# Altium schematic sheet Circuit_SCS_Error.SchDoc(Circuit_SCS_Error)
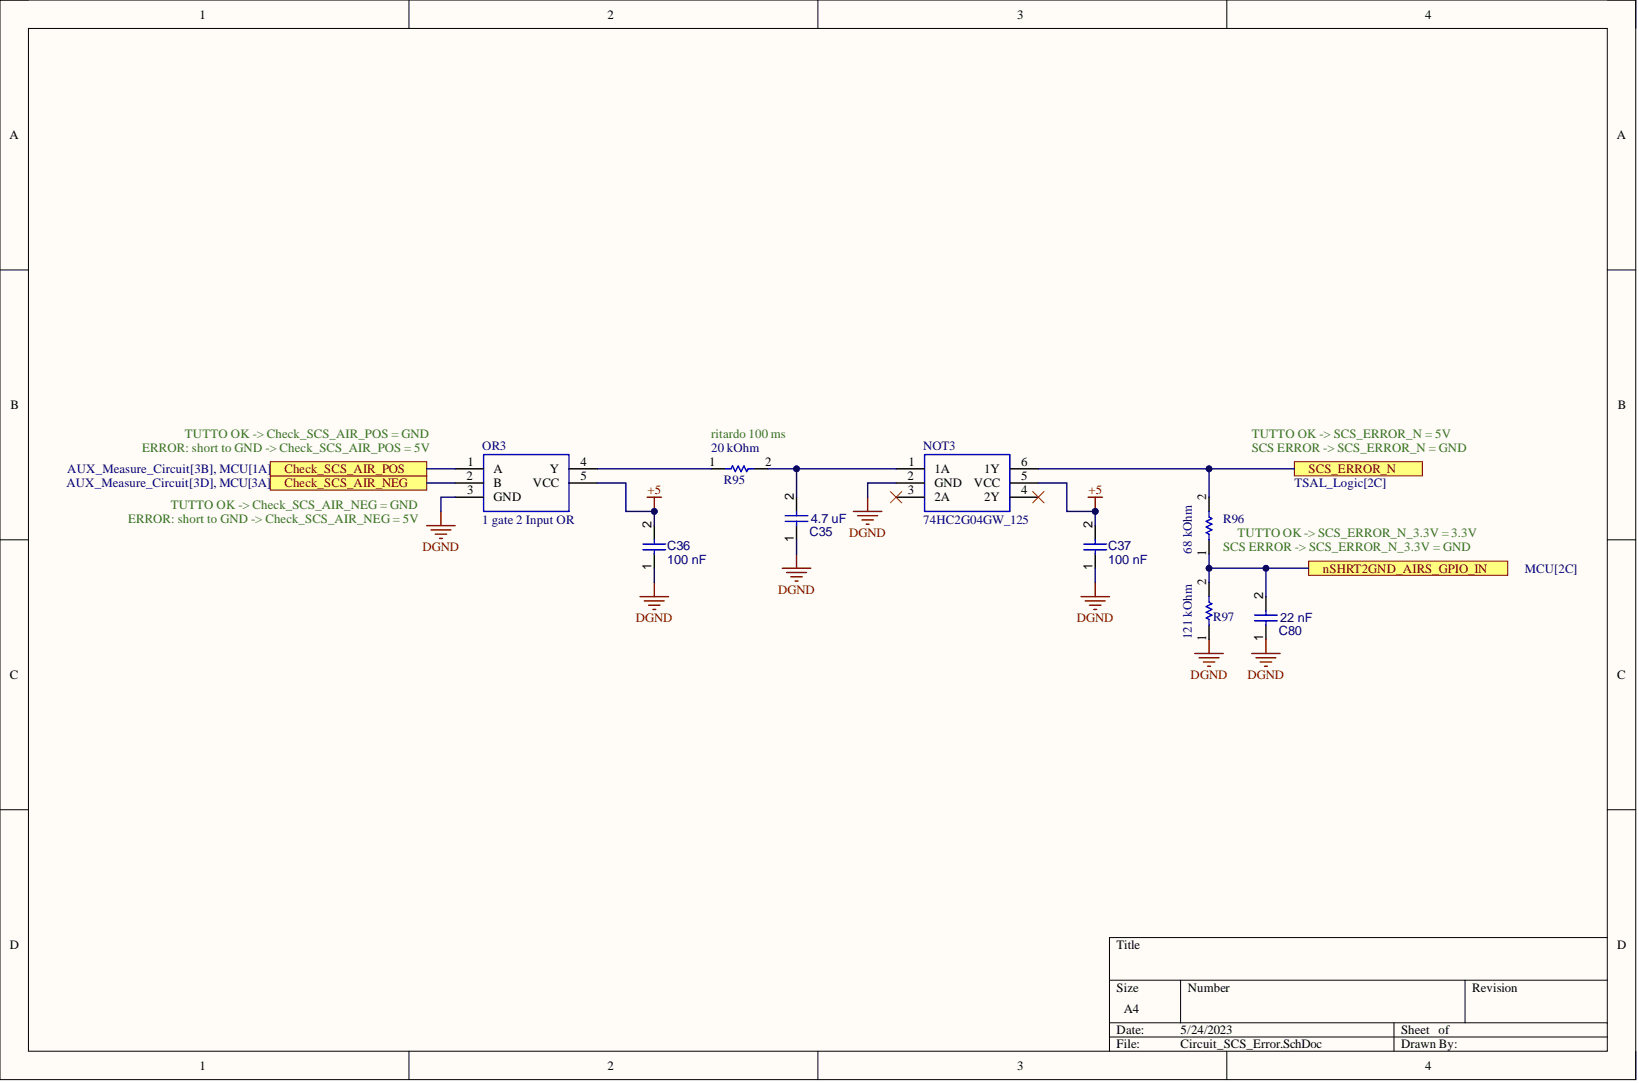
[diagram p0: Circuit_SCS_Error.SchDoc]

## Parts
C35  4.7 uF
C36  100 nF
C37  100 nF
C80  22 nF
NOT3  74HC2G04GW_125
OR3  1 gate 2 Input OR
R95  20 kOhm
R96  68 kOhm
R97  121 kOhm

## Ports
Check_SCS_AIR_NEG, Check_SCS_AIR_POS, nSHRT2GND_AIRS_GPIO_IN, SCS_ERROR_N

## Nets
+5: C36.2 C37.2 NOT3.5(VCC) OR3.5(VCC)
DGND: C35.1 C36.1 C37.1 C80.1 NOT3.2(GND) OR3.3(GND) R97.1
Check_SCS_AIR_NEG: OR3.2(B) ; port Check_SCS_AIR_NEG
Check_SCS_AIR_POS: OR3.1(A) ; port Check_SCS_AIR_POS
nSHRT2GND_AIRS_GPIO_IN: C80.2 R96.1 R97.2 ; port nSHRT2GND_AIRS_GPIO_IN
SCS_ERROR_N: NOT3.6(1Y) R96.2 ; port SCS_ERROR_N
NetC35_2: C35.2 NOT3.1(1A) R95.2
NetOR3_4: OR3.4(Y) R95.1

Not connected: NOT3 3(2A) 4(2Y)

## Library data of the parts
C35 = CMP-001-00085-2: GCJ21BR71C475KA01L; Tech: SMD; Component Kind: Standard; ComponentLink1Description: Website; ComponentLink1URL: https://www.mouser.it/ProductDetail/Vishay-Vitramon/VJ0805G475MXXTW1BC?qs=PqoDHHvF6488%252BUk4EHTgKw%3D%3D; Package: 0805; Library Name: EMEA- Squadra Corse PoliTO-  Politecnico di Torino
C36 C37 = CMP-001-00085-2: VJ0805Y104MXATW1BC; Tech: SMD; Component Kind: Standard; ComponentLink1Description: Website; ComponentLink1URL: https://www.mouser.it/ProductDetail/KYOCERA-AVX/08055C104KAT4A?qs=2ujN4bDBNE8aFT7CFyBStg%3D%3D; Package: 0805; Library Name: EMEA- Squadra Corse PoliTO-  Politecnico di Torino
C80 = CMP-001-00085-2: VJ0805Y223JXACW1BC; Tech: SMD; Component Kind: Standard; ComponentLink1Description: Website; ComponentLink1URL: https://www.mouser.it/ProductDetail/Vishay-Vitramon/VJ0805Y223JXXPW1BC?qs=dYENeDlLSFWEZ4cdRF5phA%3D%3D; Package: 0805; Library Name: EMEA- Squadra Corse PoliTO-  Politecnico di Torino
NOT3 = CMP-071-000000-2: NOT 2 CIRCUITS; Tech: SMD; Component Kind: Standard; ComponentLink1Description: Website; ComponentLink1URL: https://www.mouser.it/ProductDetail/Nexperia/74HC2G04GW125?qs=P62ublwmbi8EHFb4JRBQoA%3D%3D; Package: TSSOP-6; Library Name: EMEA- Squadra Corse PoliTO-  Politecnico di Torino
OR3 = CMP-002-000003-1: SN74AHC1G32DBVT; Manufacturer_Name: Texas Instruments; Geometry.Height: 1.45mm; Component Kind: Standard; ComponentLink1Description: Website; ComponentLink1URL: https://www.mouser.it/ProductDetail/Texas-Instruments/SN74AHC1G32DBVT?qs=fSCvMSq5ISVX4j%2FpWgAWfA%3D%3D; Datasheet Link: http://www.ti.com/general/docs/lit/getliterature.tsp?genericPartNumber=sn74ahc1g32&fileType=pdf; Design Item ID: SN74AHC1G32DBVT; Manufacturer_Part_Number: SN74AHC1G32DBVT; Mouser Part Number: 595-SN74AHC1G32DBVT; Mouser Price/Stock: https://www.mouser.co.uk/ProductDetail/Texas-Instruments/SN74AHC1G32DBVT?qs=fSCvMSq5ISVX4j%2FpWgAWfA%3D%3D; Source: SN74AHC1G32DBVT.SchLib; Library Name: EMEA- Squadra Corse PoliTO-  Politecnico di Torino
R95 = CMP-009-00179-4: CRCW060320K0FKEAC; Tech: SMD; Component Kind: Standard; ComponentLink1Description: Website; ComponentLink1URL: https://www.mouser.it/ProductDetail/Vishay-Dale/CRCW060320K0FKEB?qs=%252BZOPz%252B2NLYVQrVjDVZxTrA%3D%3D; Package: 0603; Library Name: EMEA- Squadra Corse PoliTO-  Politecnico di Torino
R96 = CMP-009-00179-4: CRCW060368K0FKEAC; Tech: SMD; Component Kind: Standard; ComponentLink1Description: Website; ComponentLink1URL: https://www.mouser.it/ProductDetail/Vishay-Dale/CRCW060368K0FKEAC?qs=E3Y5ESvWgWOWm3dIamBggg%3D%3D; Package: 0603; Library Name: EMEA- Squadra Corse PoliTO-  Politecnico di Torino
R97 = CMP-009-00179-4: CRCW0603121KFKEA; Tech: SMD; Component Kind: Standard; ComponentLink1Description: Website; ComponentLink1URL: https://www.mouser.it/ProductDetail/Vishay-Dale/CRCW0603121KFKEA?qs=SNwsiWikH8rxaNKbUsRIDA%3D%3D; Package: 0603; Library Name: EMEA- Squadra Corse PoliTO-  Politecnico di Torino
